# Altium schematic sheet DRIVER.SchDoc(DRIVER)
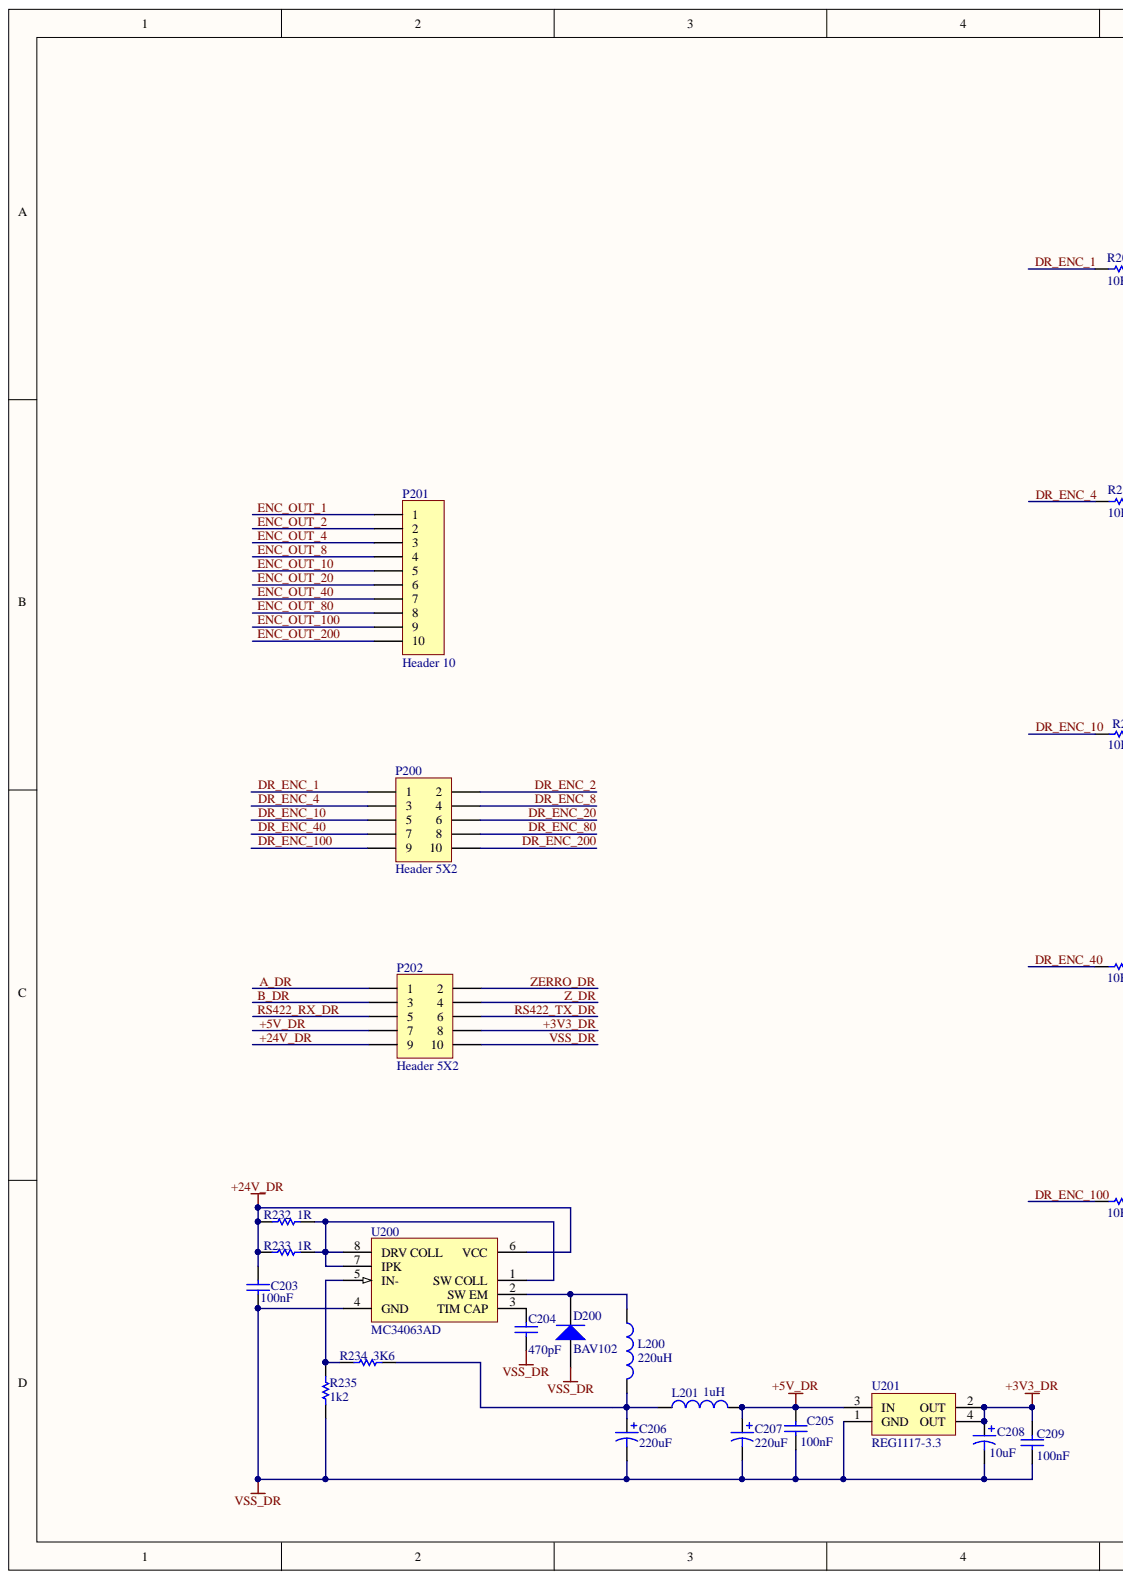
[diagram p0: DRIVER.SchDoc, left]
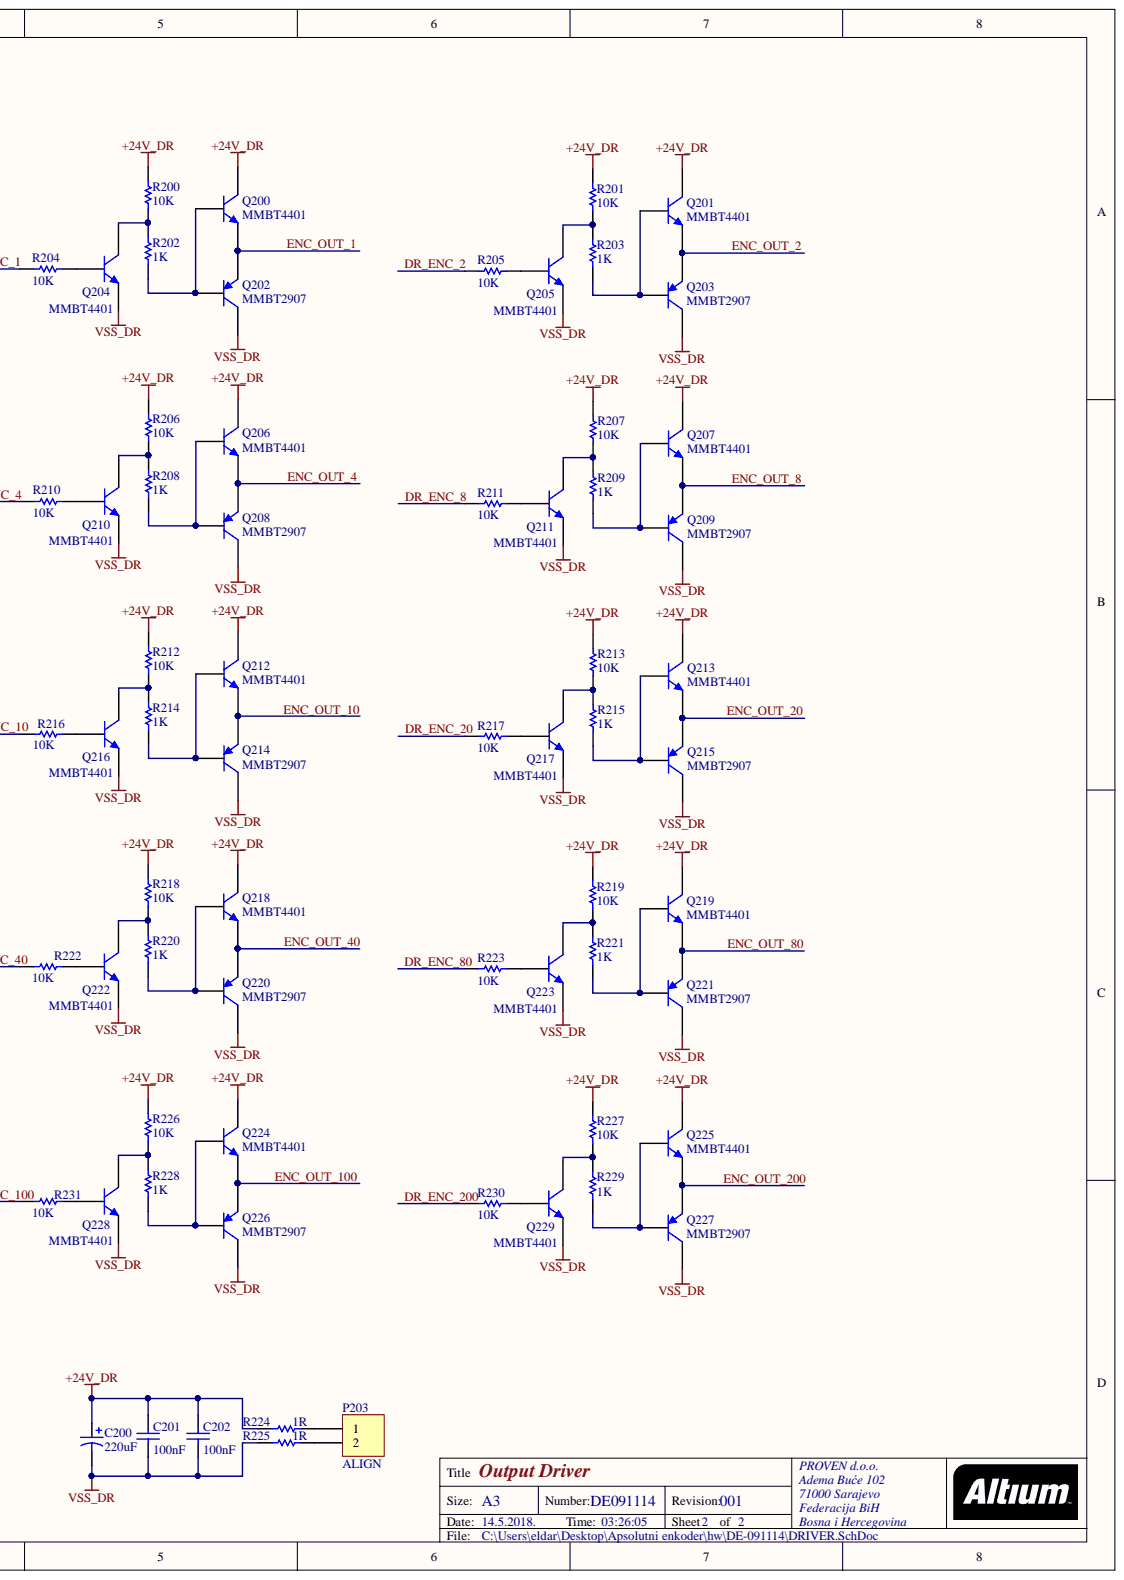
[diagram p1: DRIVER.SchDoc, right]

## Parts
C200  220uF  [E]
C201  value 100nF  [0805]
C202  value 100nF  [0805]
C203  value 100nF  [1206]
C204  value 470pF  [0805]
C205  value 100nF  [0805]
C206  220uF  [CAPPR2-5X11]
C207  220uF  [CAPPR2-5X11]
C208  10uF  [49BC-C]
C209  value 100nF  [0805]
D200  BAV102  [SOD80C]
L200  value 220uH  [RB5-10.5]
L201  value 1uH  [RB5-10.5]
P200  Header 5X2  [HDR2X5]
P201  Header 10  [HDR1X10]
P202  Header 5X2  [HDR2X5]
P203  ALIGN  [HDR1X2]
Q200  MMBT4401  [SOT23_N]
Q201  MMBT4401  [SOT23_N]
Q202  MMBT2907  [SOT23_N]
Q203  MMBT2907  [SOT23_N]
Q204  MMBT4401  [SOT23_N]
Q205  MMBT4401  [SOT23_N]
Q206  MMBT4401  [SOT23_N]
Q207  MMBT4401  [SOT23_N]
Q208  MMBT2907  [SOT23_N]
Q209  MMBT2907  [SOT23_N]
Q210  MMBT4401  [SOT23_N]
Q211  MMBT4401  [SOT23_N]
Q212  MMBT4401  [SOT23_N]
Q213  MMBT4401  [SOT23_N]
Q214  MMBT2907  [SOT23_N]
Q215  MMBT2907  [SOT23_N]
Q216  MMBT4401  [SOT23_N]
Q217  MMBT4401  [SOT23_N]
Q218  MMBT4401  [SOT23_N]
Q219  MMBT4401  [SOT23_N]
Q220  MMBT2907  [SOT23_N]
Q221  MMBT2907  [SOT23_N]
Q222  MMBT4401  [SOT23_N]
Q223  MMBT4401  [SOT23_N]
Q224  MMBT4401  [SOT23_N]
Q225  MMBT4401  [SOT23_N]
Q226  MMBT2907  [SOT23_N]
Q227  MMBT2907  [SOT23_N]
Q228  MMBT4401  [SOT23_N]
Q229  MMBT4401  [SOT23_N]
R200  10K  [ERA-0603]
R201  10K  [ERA-0603]
R202  1K  [ERA-0603]
R203  1K  [ERA-0603]
R204  10K  [ERA-0603]
R205  10K  [ERA-0603]
R206  10K  [ERA-0603]
R207  10K  [ERA-0603]
R208  1K  [ERA-0603]
R209  1K  [ERA-0603]
R210  10K  [ERA-0603]
R211  10K  [ERA-0603]
R212  10K  [ERA-0603]
R213  10K  [ERA-0603]
R214  1K  [ERA-0603]
R215  1K  [ERA-0603]
R216  10K  [ERA-0603]
R217  10K  [ERA-0603]
R218  10K  [ERA-0603]
R219  10K  [ERA-0603]
R220  1K  [ERA-0603]
R221  1K  [ERA-0603]
R222  10K  [ERA-0603]
R223  10K  [ERA-0603]
R224  1R  [ERA-0603]
R225  1R  [ERA-0603]
R226  10K  [ERA-0603]
R227  10K  [ERA-0603]
R228  1K  [ERA-0603]
R229  1K  [ERA-0603]
R230  10K  [ERA-0603]
R231  10K  [ERA-0603]
R232  1R  [ERA-0805]
R233  1R  [ERA-0805]
R234  3K6  [ERA-0805]
R235  1k2  [ERA-0805]
U200  MC34063AD  [751-05_N]
U201  REG1117-3.3  [ZZ311]

## Nets
+3V3_DR: C208.1 C209.2 P202.8 U201.2(OUT) U201.4(OUT)
+5V_DR: C205.2 C207.1 L201.2 P202.7 U201.3(IN)
+24V_DR: C200.1 C201.2 C202.2 C203.2 P202.9 Q200.3 Q201.3 Q206.3 Q207.3 Q212.3 Q213.3 Q218.3 Q219.3 Q224.3 Q225.3 R200.1 R201.1 R206.1 R207.1 R212.1 R213.1 R218.1 R219.1 R224.1 R226.1 R227.1 R232.1 R233.1 U200.6(VCC)
A_DR: P202.1
B_DR: P202.3
DR_ENC_1: P200.1 R204.2
DR_ENC_2: P200.2 R205.2
DR_ENC_4: P200.3 R210.2
DR_ENC_8: P200.4 R211.2
DR_ENC_10: P200.5 R216.2
DR_ENC_20: P200.6 R217.2
DR_ENC_40: P200.7 R222.2
DR_ENC_80: P200.8 R223.2
DR_ENC_100: P200.9 R231.2
DR_ENC_200: P200.10 R230.2
ENC_OUT_1: P201.1 Q200.2 Q202.2
ENC_OUT_2: P201.2 Q201.2 Q203.2
ENC_OUT_4: P201.3 Q206.2 Q208.2
ENC_OUT_8: P201.4 Q207.2 Q209.2
ENC_OUT_10: P201.5 Q212.2 Q214.2
ENC_OUT_20: P201.6 Q213.2 Q215.2
ENC_OUT_40: P201.7 Q218.2 Q220.2
ENC_OUT_80: P201.8 Q219.2 Q221.2
ENC_OUT_100: P201.9 Q224.2 Q226.2
ENC_OUT_200: P201.10 Q225.2 Q227.2
RS422_RX_DR: P202.5
RS422_TX_DR: P202.6
VSS_DR: C200.2 C201.1 C202.1 C203.1 C204.1 C205.1 C206.2 C207.2 C208.2 C209.1 D200.1 P202.10 Q202.3 Q203.3 Q204.2 Q205.2 Q208.3 Q209.3 Q210.2 Q211.2 Q214.3 Q215.3 Q216.2 Q217.2 Q220.3 Q221.3 Q222.2 Q223.2 Q226.3 Q227.3 Q228.2 Q229.2 R225.1 R235.1 U200.4(GND) U201.1(GND)
Z_DR: P202.4
ZERRO_DR: P202.2
NetC204_2: C204.2 U200.3(TIM_CAP)
NetC206_1: C206.1 L200.2 L201.1 R234.2
NetD200_2: D200.2 L200.1 U200.2(SW_EM)
NetP203_1: P203.1 R224.2
NetP203_2: P203.2 R225.2
NetQ200_1: Q200.1 Q202.1 R202.1
NetQ201_1: Q201.1 Q203.1 R203.1
NetQ204_1: Q204.1 R204.1
NetQ204_3: Q204.3 R200.2 R202.2
NetQ205_1: Q205.1 R205.1
NetQ205_3: Q205.3 R201.2 R203.2
NetQ206_1: Q206.1 Q208.1 R208.1
NetQ207_1: Q207.1 Q209.1 R209.1
NetQ210_1: Q210.1 R210.1
NetQ210_3: Q210.3 R206.2 R208.2
NetQ211_1: Q211.1 R211.1
NetQ211_3: Q211.3 R207.2 R209.2
NetQ212_1: Q212.1 Q214.1 R214.1
NetQ213_1: Q213.1 Q215.1 R215.1
NetQ216_1: Q216.1 R216.1
NetQ216_3: Q216.3 R212.2 R214.2
NetQ217_1: Q217.1 R217.1
NetQ217_3: Q217.3 R213.2 R215.2
NetQ218_1: Q218.1 Q220.1 R220.1
NetQ219_1: Q219.1 Q221.1 R221.1
NetQ222_1: Q222.1 R222.1
NetQ222_3: Q222.3 R218.2 R220.2
NetQ223_1: Q223.1 R223.1
NetQ223_3: Q223.3 R219.2 R221.2
NetQ224_1: Q224.1 Q226.1 R228.1
NetQ225_1: Q225.1 Q227.1 R229.1
NetQ228_1: Q228.1 R231.1
NetQ228_3: Q228.3 R226.2 R228.2
NetQ229_1: Q229.1 R230.1
NetQ229_3: Q229.3 R227.2 R229.2
NetR232_2: R232.2 R233.2 U200.1(SW_COLL) U200.7(IPK) U200.8(DRV_COLL)
NetR234_1: R234.1 R235.2 U200.5(IN-)

## Library data of the parts
C200 = 94SS107X0020EBP: Solid Aluminum Capacitor with Organic Semiconductor Electrolyte: 100uF, 20V, Bulk Pack; PCB_Layout: Complies with Manufacturer's Recommendation.; Note: Part Numbers shown are for ± 20% capacitance tolerance (X0).; DrillSize: Nominal, Rounded to the nearest 0.1mm; Value: 100uF; Simulation: CAP; Signal Integrity: 94SS107X0020EBP; Published: 19-Mar-2004; PackageReference: E; Library Name: Vishay Electrolytic Radial-Lead Capacitor.IntLib; DatasheetDocument: 23-May-2001; ComponentLink2URL: http://www.vishay.com/docs/90008/94ss.pdf; ComponentLink2Description: Datasheet; ComponentLink1URL: http://www.vishay.com/; ComponentLink1Description: Manufacturer Link; Component Kind: Standard; Publisher: Altium Limited
C201 C202 C204 C205 C209 = C0805: Ceramic Chip Capacitor - Standard; Note: Footprint corresponds to dimensions for bulk cassette packaging. This case size has tightened tolerances.; Simulation: CAP; Signal Integrity: C0805; Published: 17-Jul-2002; PackageReference: 0805; PackageDocument: Mar-2001; Library Name: KEMET Chip Capacitor.IntLib; LatestRevisionNote: Silkscreen size reduced.; LatestRevisionDate: 19-Mar-2004; DatasheetDocument: Mar-2001; ComponentLink2URL: http://www.kemet.com/kemet/web/homepage/kechome.nsf/vapubfilesname/F3102TA.pdf/$file/F3102TA.pdf; ComponentLink2Description: Datasheet; ComponentLink1URL: http://www.kemet.com/; ComponentLink1Description: Manufacturer Link; Component Kind: Standard; Code_JEITA: 0805; Code_IPC: 2012[0805]; Publisher: Altium Limited
C203 = C1206: Ceramic Chip Capacitor - Standard; Simulation: CAP; Signal Integrity: C1206; Published: 17-Jul-2002; PackageReference: 1206; PackageDocument: Mar-2001; Library Name: KEMET Chip Capacitor.IntLib; LatestRevisionNote: Silkscreen size reduced.; LatestRevisionDate: 19-Mar-2004; DatasheetDocument: Mar-2001; ComponentLink2URL: http://www.kemet.com/kemet/web/homepage/kechome.nsf/vapubfilesname/F3102TA.pdf/$file/F3102TA.pdf; ComponentLink2Description: Datasheet; ComponentLink1URL: http://www.kemet.com/; ComponentLink1Description: Manufacturer Link; Component Kind: Standard; Code_JEITA: 1206; Code_IPC: 3216 [1206]; Publisher: Altium Limited
C206 C207 = EKA00AA322C00: Aluminum Electrolytic Capacitor, Radial Style with Long Leads: 220uF, 10V; Note: Refer to Manufacturer's Data Sheets for other lead formations; DrillSize: Nominal, Rounded To The Nearest 0.1mm; Value: 220uF; Simulation: CAP; Signal Integrity: EKA00AA322C00; Published: 19-Mar-2004; PackageReference: CAPPR2-5X11; DatasheetDocument: 20-Feb-2001; ComponentLink2URL: http://www.vishay.com/docs/25014/eka.pdf; ComponentLink2Description: Datasheet; ComponentLink1URL: http://www.vishay.com/; ComponentLink1Description: Manufacturer Link; Component Kind: Standard; Publisher: Altium Limited; Library Name: Vishay Roederstein Electrolytic Radial-Lead Capacitor.IntLib
C208 = 49BC685B010M: Conformal Coated Solid Tantalum Chip Capacitor: 6.8uF, 20% Tolerance, 10 WVDC @ -55°C to +85°C, Surge = 13V; Note: See Data Sheet for full range of components; Value: 6.8uF; Simulation: CAP; Signal Integrity: 49BC685B010M; Published: 19-Mar-2004; PackageReference: 49BC-C; DatasheetDocument: 05-Jul-2002; ComponentLink1URL: http://www.vishay.com/; ComponentLink1Description: Manufacturer Link; Component Kind: Standard; Publisher: Altium Limited; Library Name: Vishay Sprague Tantalum Chip Capacitor.IntLib
D200 = BAV102: General-purpose Diode; Simulation: BAV103; Signal Integrity: BAV102; Published: 17-Sep-2003; PackageVersion: Jan-2002; PackageReference: SOD80C; PackageDescription: Metal Electrode Face (MELF) Diode; Body 3.5 x 1.6 mm (LxDia); Library Name: Philips Discrete Diode - Switching.IntLib; LatestRevisionNote: Silkscreen size reduced.; LatestRevisionDate: 19-Mar-2004; DatasheetVersion: 17-Sep-1996; ComponentLink2URL: http://www.semiconductors.philips.com/acrobat/datasheets/BAV100_2.pdf; ComponentLink2Description: Datasheet; ComponentLink1URL: http://www.semiconductors.philips.com/; ComponentLink1Description: Manufacturer Link; Component Kind: Standard; Publisher: Altium Limited
L200 L201 = PCMC063T-R82MN: SMT Power Choke Coil; Size 7.3×6.6×3.0mm, ±20% Tolerance, 0.82uH, 13A; PCB_Layout: Complies with manufacturer's recommendation.; Simulation: INDUCTOR; Signal Integrity: Inductor; Published: 26-Sep-2008; PackageVersion: Oct-2007; PackageReference: PCMC063T; PackageDescription: SM Power Choke Coil; 2 Leads; Body 7.3 x 6.6 x 3.0 mm (LxWxH); Library Name: SSM Inductor.IntLib; DatasheetVersion: Oct-2007; ComponentLink2URL: http://www.susumu.co.jp/english/pdf/products-j07-01.pdf; ComponentLink2Description: Datasheet; ComponentLink1URL: http://www.susumu.co.jp/; ComponentLink1Description: Manufacturer Link; Component Kind: Standard; Publisher: Altium Limited
P200 P202 = Header 5X2: Header, 5-Pin, Dual row; Signal Integrity: Connector; Library Name: Miscellaneous Connectors.IntLib; LatestRevisionNote: Re-released for DXP Platform.; LatestRevisionDate: 17-Jul-2002; Component Kind: Standard; Publisher: Altium Limited
P201 = Header 10: Header, 10-Pin; Signal Integrity: Connector; Library Name: Miscellaneous Connectors.IntLib; LatestRevisionNote: Re-released for DXP Platform.; LatestRevisionDate: 17-Jul-2002; Component Kind: Standard; Publisher: Altium Limited
P203 = Header 2: Header, 2-Pin; Signal Integrity: Connector; Library Name: Miscellaneous Connectors.IntLib; LatestRevisionNote: Re-released for DXP Platform.; LatestRevisionDate: 17-Jul-2002; Component Kind: Standard; Publisher: Altium Limited
Q200 Q201 Q204-Q207 Q210-Q213 Q216-Q219 Q222-Q225 Q228 Q229 = BC817-16: NPN General-purpose Transistor; Simulation: QBC817-16; Signal Integrity: BC817-16; Published: 17-Sep-2003; PackageVersion: May-1999; PackageReference: SOT23; PackageDescription: 3-Pin SOT-23 Package 0.95 mm Pitch, 2.3 mm Lead Span; Library Name: Philips Discrete BJT - Low Power.IntLib; LatestRevisionNote: IPC-7351 Footprint Added.; LatestRevisionDate: 12-Dec-2006; DatasheetVersion: Jun-1999; ComponentLink2URL: http://www.semiconductors.philips.com/acrobat/datasheets/BC817_BC817W_BC337_5.pdf; ComponentLink2Description: Datasheet; ComponentLink1URL: http://www.semiconductors.philips.com/; ComponentLink1Description: Manufacturer Link; Component Kind: Standard; Publisher: Altium Limited
Q202 Q203 Q208 Q209 Q214 Q215 Q220 Q221 Q226 Q227 = BC807-16: PNP General-purpose Transistor; Signal Integrity: BC807-16; Published: 17-Sep-2003; PackageVersion: May-1999; PackageReference: SOT23; PackageDescription: 3-Pin SOT-23 Package 0.95 mm Pitch, 2.3 mm Lead Span; Library Name: Philips Discrete BJT - Low Power.IntLib; LatestRevisionNote: IPC-7351 Footprint Added.; LatestRevisionDate: 12-Dec-2006; DatasheetVersion: 8-Apr-1999; ComponentLink2URL: http://www.semiconductors.philips.com/acrobat/datasheets/BC807_BC807W_BC327_5.pdf; ComponentLink2Description: Datasheet; ComponentLink1URL: http://www.semiconductors.philips.com/; ComponentLink1Description: Manufacturer Link; Component Kind: Standard; Publisher: Altium Limited
R200-R231 = ERAV: Metal Film Thermosensitive Chip Resistor, 0.56 Ohm to 10k Ohm Range, 5% Tolerance, 0603 Size, 0.063 W; Note: If flow soldering, adjust pad width of PCB Footprint to 0.7 - 0.8 times the width of the resistor.; Simulation: RESISTOR; Signal Integrity: Res; Published: 17-Dec-2003; PackageReference: ERA-0603; PackageDocument: Mar-2003; Library Name: Panasonic Resistor.IntLib; LatestRevisionNote: Silkscreen size reduced.; LatestRevisionDate: 19-Mar-2004; ComponentLink2URL: http://www.panasonic.com/industrial/components/pdf/AOF0000CE1.pdf; ComponentLink2Description: Datasheet; ComponentLink1URL: http://www.panasonic.com/industrial/components/resistive_products.htm; ComponentLink1Description: Manufacturer Link; Component Kind: Standard; Code_IPC: 1608 [0603]; Publisher: Altium Limited
R232-R235 = ERAS: Metal Film Thermosensitive Chip Resistor, 0.56 Ohm to 10k Ohm Range, 5% Tolerance, 0805 Size, 0.1 W; Note: If flow soldering, adjust pad width of PCB Footprint to 0.7 - 0.8 times the width of the resistor.; Simulation: RESISTOR; Signal Integrity: Res; Published: 17-Dec-2003; PackageReference: ERA-0805; PackageDocument: Mar-2003; Library Name: Panasonic Resistor.IntLib; LatestRevisionNote: Silkscreen size reduced.; LatestRevisionDate: 19-Mar-2004; ComponentLink2URL: http://www.panasonic.com/industrial/components/pdf/AOF0000CE1.pdf; ComponentLink2Description: Datasheet; ComponentLink1URL: http://www.panasonic.com/industrial/components/resistive_products.htm; ComponentLink1Description: Manufacturer Link; Component Kind: Standard; Code_IPC: 2012 [0805]; Publisher: Altium Limited
U200 = MC34063AD: DC-to-DC Converter Control Circuit; Published: 15-Apr-2002; PackageVersion: 1998; PackageReference: 751-05; PackageDescription: 8-Pin Small Outline Integrated Circuit 1.27 mm Pitch; Library Name: ON Semi Power Mgt DC-DC Converter.IntLib; LatestRevisionNote: IPC-7351 Footprint Added.; LatestRevisionDate: 13-Apr-2006; DatasheetVersion: 1996; ComponentLink2URL: http://www.onsemi.com/pub/Collateral/MC34063A-D.PDF; ComponentLink2Description: Datasheet; ComponentLink1URL: http://www.onsemi.com/site/products/taxonomy/0,4438,503,00.html; ComponentLink1Description: Manufacturer Link; Component Kind: Standard; Code_JEDEC: MS-012-AA; Code_IPC: SOIC127P600-8; Publisher: Altium Limited
U201 = REG1117-3.3: 800mA and 1A Low Dropout Positive Regulator 2.85V, 3.3V, 5V, and Adjustable; Published: 8-Jun-2000; PackageVersion: revC; PackageReference: ZZ311; PackageDescription: SOT-223/SC-73; 4 Leads; Body 6.7 x 7.3 mm, inc. leads (LxW max); Library Name: BB Power Mgt Voltage Regulator.IntLib; LatestRevisionNote: Re-released for DXP Platform.; LatestRevisionDate: 17-Jul-2002; DatasheetVersion: Sep-1997; ComponentLink2URL: http://www-s.ti.com/sc/ds/REG1117.pdf; ComponentLink2Description: Datasheet; ComponentLink1URL: http://www.ti.com/; ComponentLink1Description: Manufacturer Link; Component Kind: Standard; Publisher: Altium Limited
